# Altium schematic sheet 09 F411 1S AIO -F411 V1.1.SchDoc
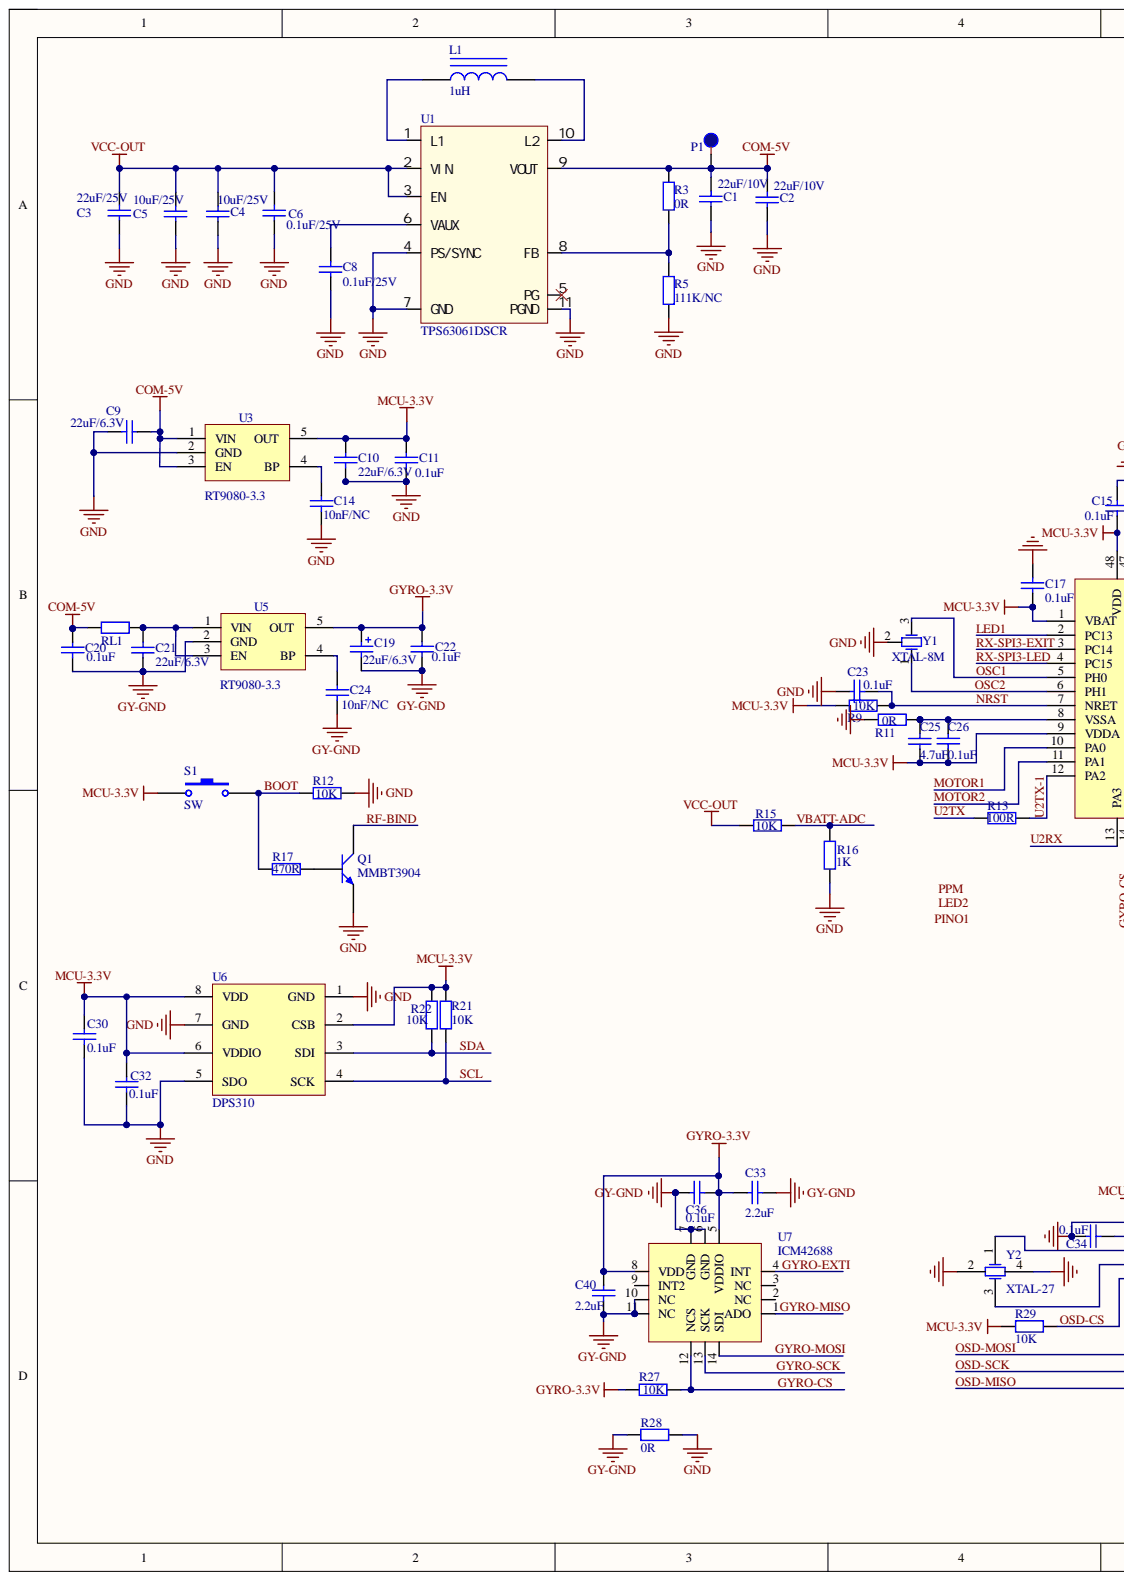
[diagram p0: 09 F411 1S AIO -F411 V1.1.SchDoc, left]
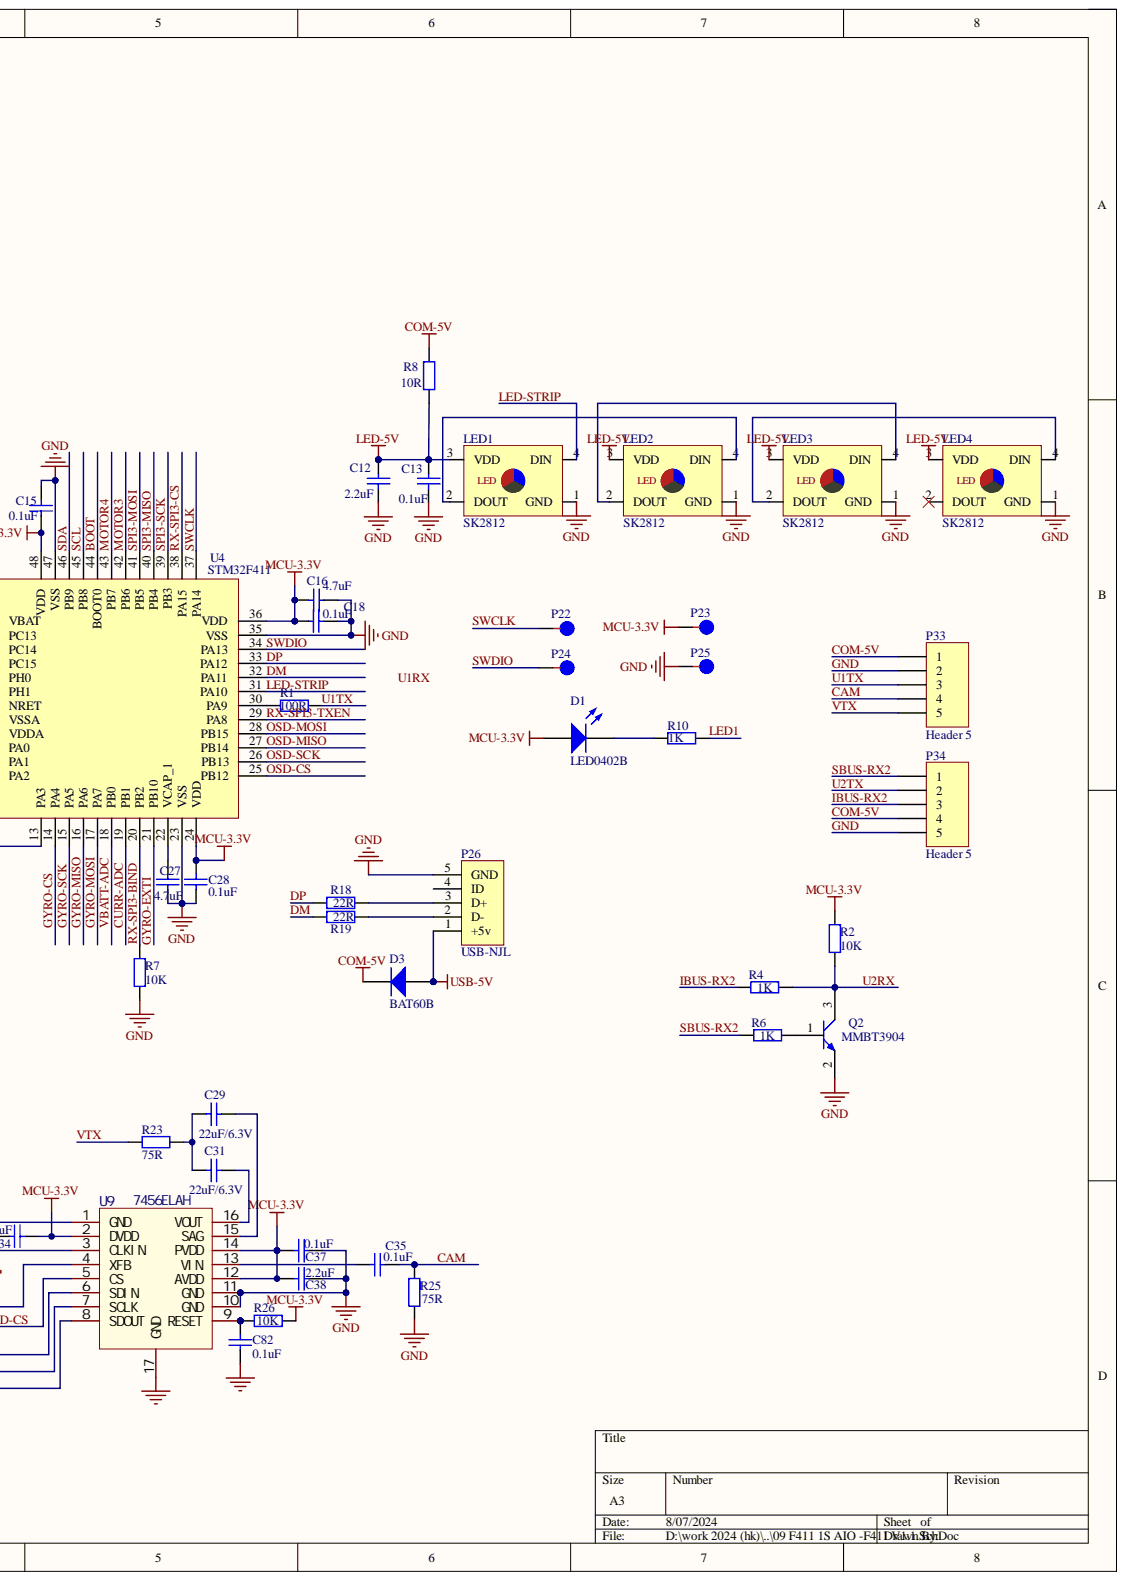
[diagram p1: 09 F411 1S AIO -F411 V1.1.SchDoc, right]

## Parts
C1  22uF/10V  [C0603]
C2  22uF/10V  [C0603]
C3  22uF/25V  [C0805]
C4  10uF/25V  [C0603]
C5  10uF/25V  [C0603]
C6  0.1uF/25V  [C0402]
C8  0.1uF/25V  [C0402]
C9  22uF/6.3V  [C0603]
C10  22uF/6.3V  [C0603]
C11  0.1uF  [C0201]
C12  0.1uF  value 2.2uF  [C0201]
C13  0.1uF  [C0201]
C14  10nF/NC  [C0201]
C15  0.1uF  [C0201]
C16  4.7uF  [C0201]
C17  0.1uF  [C0201]
C18  0.1uF  [C0201]
C19  22uF/6.3V  [C0805-TAN]
C20  0.1uF  [C0201]
C21  22uF/6.3V  [C0603]
C22  0.1uF  [C0201]
C23  0.1uF  [C0201]
C24  10nF/NC  [C0201]
C25  4.7uF  [C0201]
C26  0.1uF  [C0201]
C27  4.7uF  [C0201]
C28  0.1uF  [C0201]
C29  22uF  value 22uF/6.3V  [C0603]
C30  0.1uF  [C0201]
C31  22uF  value 22uF/6.3V  [C0603]
C32  0.1uF  [C0201]
C33  2.2uF  [C0201]
C34  0.1uF  [C0201]
C35  0.1uF  [C0201]
C36  0.1uF  [C0201]
C37  0.1uF  [C0201]
C38  2.2uF  [C0201]
C40  2.2uF  [C0201]
C82  0.1uF  [C0201]
D1  LED0402B  [LED0402B]
D3  BAT60B  [BAT60B]
L1  1uH  [L3*3]
LED1  SK2812  [LEDWS2812-C]
LED2  SK2812  [LEDWS2812-C]
LED3  SK2812  [LEDWS2812-C]
LED4  SK2812  [LEDWS2812-C]
P1  (no value)  [TP08]
P22  (no value)  [TP08]
P23  (no value)  [TP08]
P24  (no value)  [TP08]
P25  (no value)  [TP08]
P26  USB-NJL  [USB-C2909202]
P33  Header 5  [SURS08-5P]
P34  Header 5  [SURS08-5P]
Q1  MMBT3904  [SOT-723-3]
Q2  MMBT3904  [SOT-723-3]
R1  100R  [R0201]
R2  10K  [R0201]
R3  0R  [R0201]
R4  1K  [R0201]
R5  111K/NC  [R0201]
R6  1K  [R0201]
R7  10K  [R0201]
R8  10R  [R0805]
R9  10K  [R0201]
R10  1K  [R0201]
R11  0R  [R0201]
R12  10K  [R0201]
R13  100R  [R0201]
R15  10K  [R0201]
R16  1K  [R0201]
R17  470R  [R0201]
R18  22R  [R0201]
R19  22R  [R0201]
R21  10K  [R0201]
R22  10K  [R0201]
R23  75R  [R0201]
R25  75R  [R0201]
R26  10K  [R0201]
R27  10K  [R0201]
R28  0R  [R0201]
R29  10K  [R0201]
RL1  FB  [R0402]  (value not drawn)
S1  SW  [SMD-2]
U1  TPS63061DSCR  [WSON-10P]
U3  RT9080-3.3  [RT9193]
U4  STM32F411  [STM32F411]
U5  RT9080-3.3  [RT9193]
U6  DPS310  [BMP280]
U7  ICM42688  [BMI270]
U9  7456ELAH  [7456F]
Y1  XTAL-8M  [XTAL3315]
Y2  XTAL-27  [XTL-2016]

## Nets
GY-GND: C19.2 C20.2 C21.1 C22.2 C24.2 C33.2 C36.1 C40.2 R28.2 U5.2(GND) U7.6(GND) U7.7(GND) U7.10(NC) U7.11(NC)
GYRO-3.3V: C19.1 C22.1 C33.1 C36.2 C40.1 R27.1 U5.5(OUT) U7.5(VDDIO) U7.8(VDD)
LED-5V: C12.1 C13.1 LED1.3(VDD) LED2.3(VDD) LED3.3(VDD) LED4.3(VDD) R8.2
MCU-3.3V: C10.1 C11.1 C15.1 C16.2 C17.1 C18.1 C25.1 C26.2 C28.2 C30.1 C32.1 C34.2 C37.2 C38.2 D1.1 P23.1 R2.1 R9.2 R21.2 R22.2 R26.2 R29.1 S1.1 U3.5(OUT) U4.1(VBAT) U4.9(VDDA) U4.24(VDD) U4.36(VDD) U4.48(VDD) U6.2(CSB) U6.6(VDDIO) U6.8(VDD) U9.2(DVDD) U9.12(AVDD) U9.14(PVDD)
USB-5V: D3.1 P26.1(+5v)
VCC-OUT: C3.2 C4.2 C5.2 C6.2 R15.1 U1.2(VIN) U1.3(EN)
BOOT: R12.2 R17.1 S1.2 U4.44(BOOT0)
CAM: C35.1 P33.4 R25.1
COM-5V: C1.2 C2.2 C9.1 C20.1 D3.2 P1.1 P33.1 P34.4 R3.1 R8.1 RL1.2 U1.9(VOUT) U3.1(VIN) U3.3(EN)
CURR-ADC: U4.19(PB1)
DM: R19.1 U4.32(PA11)
DP: R18.1 U4.33(PA12)
GND: C1.1 C2.1 C3.1 C4.1 C5.1 C6.1 C8.1 C9.2 C10.2 C11.2 C12.2 C13.2 C14.2 C15.2 C16.1 C17.2 C18.2 C23.1 C27.1 C28.1 C30.2 C32.2 C34.1 C37.1 C38.1 C82.1 LED1.1(GND) LED2.1(GND) LED3.1(GND) LED4.1(GND) P25.1 P26.5(GND) P33.2 P34.5 Q1.2 Q2.2 R5.2 R7.1 R11.2 R12.1 R16.2 R25.2 R28.1 U1.4(PS/SYNC) U1.7(GND) U1.11(PGND) U3.2(GND) U4.23(VSS) U4.35(VSS) U4.47(VSS) U6.1(GND) U6.5(SDO) U6.7(GND) U9.1(GND) U9.10(GND) U9.11(GND) U9.17(GND) Y1.2 Y2.2 Y2.4
GYRO-CS: R27.2 U4.14(PA4) U7.12(NCS)
GYRO-EXTI: U4.21(PB10) U7.4(INT)
GYRO-MISO: U4.16(PA6) U7.1(ADO)
GYRO-MOSI: U4.17(PA7) U7.14(SDI)
GYRO-SCK: U4.15(PA5) U7.13(SCK)
IBUS-RX2: P34.3 R4.2
LED1: R10.2 U4.2(PC13)
LED-STRIP: LED1.4(DIN) U4.31(PA10)
MOTOR1: U4.10(PA0)
MOTOR2: U4.11(PA1)
MOTOR3: U4.42(PB6)
MOTOR4: U4.43(PB7)
NRST: C23.2 R9.1 U4.7(NRET)
OSC1: U4.5(PH0) Y1.3
OSC2: U4.6(PH1) Y1.1
OSD-CS: R29.2 U4.25(PB12) U9.5(CS)
OSD-MISO: U4.27(PB14) U9.8(SDOUT)
OSD-MOSI: U4.28(PB15) U9.6(SDIN)
OSD-SCK: U4.26(PB13) U9.7(SCLK)
RF-BIND: Q1.3
RX-SPI3-BIND: R7.2 U4.20(PB2)
RX-SPI3-CS: U4.38(PA15)
RX-SPI3-EXIT: U4.3(PC14)
RX-SPI3-LED: U4.4(PC15)
RX-SPI3-TXEN: U4.29(PA8)
SBUS-RX2: P34.1 R6.2
SCL: R21.1 U4.45(PB8) U6.4(SCK)
SDA: R22.1 U4.46(PB9) U6.3(SDI)
SPI3-MISO: U4.40(PB4)
SPI3-MOSI: U4.41(PB5)
SPI3-SCK: U4.39(PB3)
SWCLK: P22.1 U4.37(PA14)
SWDIO: P24.1 U4.34(PA13)
U1TX: P33.3 R1.2
U2RX: Q2.3 R2.2 R4.1 U4.13(PA3)
U2TX: P34.2 R13.1
U2TX-1: R13.2 U4.12(PA2)
VBATT-ADC: R15.2 R16.1 U4.18(PB0)
VTX: P33.5 R23.1
NetC8_2: C8.2 U1.6(VAUX)
NetC14_1: C14.1 U3.4(BP)
NetC21_2: C21.2 RL1.1 U5.1(VIN) U5.3(EN)
NetC24_1: C24.1 U5.4(BP)
NetC25_2: C25.2 C26.1 R11.1 U4.8(VSSA)
NetC27_2: C27.2 U4.22(VCAP_1)
NetC29_1: C29.1 U9.15(SAG)
NetC29_2: C29.2 C31.2 R23.2
NetC31_1: C31.1 U9.16(VOUT)
NetC35_2: C35.2 U9.13(VIN)
NetC82_2: C82.2 R26.1 U9.9(RESET)
NetD1_2: D1.2 R10.1
NetL1_1: L1.1 U1.1(L1)
NetL1_2: L1.2 U1.10(L2)
NetLED1_2: LED1.2(DOUT) LED2.4(DIN)
NetLED2_2: LED2.2(DOUT) LED3.4(DIN)
NetLED3_2: LED3.2(DOUT) LED4.4(DIN)
NetP26_2: P26.2(D-) R19.2
NetP26_3: P26.3(D+) R18.2
NetQ1_1: Q1.1 R17.2
NetQ2_1: Q2.1 R6.1
NetR1_1: R1.1 U4.30(PA9)
NetR3_2: R3.2 R5.1 U1.8(FB)
NetU9_3: U9.3(CLKIN) Y2.1
NetU9_4: U9.4(XFB) Y2.3
LED2:
PINO1:
PPM:
U1RX:

Not connected: LED4 2(DOUT); P26 4(ID); U1 5(PG); U7 2(NC) 3(NC) 9(INT2)

## Library data of the parts
C1 C2 = C0805: Capacitor; Value: 22uF; Component Kind: Standard; Library Name: Integrated_Library_gr.IntLib
C3-C5 = C0805: Capacitor; Component Kind: Standard; Library Name: Integrated_Library_gr.IntLib
C6 C8 C11-C18 C20 C22-C28 C30 C32-C38 C40 C82 = C0201: Capacitor; Component Kind: Standard
  C6 C8 C14 C24: Library Name: Integrated_Library_gr.IntLib
  C11 C15 C17 C18 C20 C22 C23 C26 C28 C30 C32 C36: Library Name: Integrated_Library_gr.IntLib; Value: 0.1uF
  C12: Library Name: Miscellaneous Connectors.IntLib
  C13 C34 C35 C37 C82: Library Name: Miscellaneous Connectors.IntLib; Value: 0.1uF
  C16 C25 C27: Library Name: Integrated_Library_gr.IntLib; Value: 4.7uF
  C33 C40: Library Name: Integrated_Library_gr.IntLib; Value: 2.2uF
  C38: Library Name: Miscellaneous Connectors.IntLib; Value: 2.2uF
C9 C10 C21 = C0603: Capacitor; Value: 22uF; Component Kind: Standard; Library Name: Integrated_Library_gr.IntLib
C19 = DC1206: Capacitor; Value: 22uF; Component Kind: Standard; Library Name: Integrated_Library_gr.IntLib
C29 C31 = C0603: Capacitor; Component Kind: Standard; Library Name: Miscellaneous Connectors.IntLib
D1 = LED0603B: LED; Component Kind: Standard; Library Name: Integrated_Library_gr.IntLib
D3 = BAT60B: Diode; Component Kind: Standard; Library Name: Integrated_Library_gr.IntLib
L1 = L-7*7: Inductor; Component Kind: Standard; Library Name: Integrated_Library_gr.IntLib
LED1-LED4 = SK6812-2020LED: Component Kind: Standard; Library Name: AT32F421K8U7temp V1.0.SCHLIB
P1 P22-P25 = TP: Component Kind: Standard
P26 = USB-NJL: USB; Component Kind: Standard; Library Name: F722 BL-32 V2.0.SCHLIB
P33 P34 = Header 5: Header, 5-Pin; Component Kind: Standard; LatestRevisionDate: 17-Jul-2002; LatestRevisionNote: Re-released for DXP Platform.; Library Name: Miscellaneous Connectors.IntLib; Signal Integrity: Connector; Publisher: Altium Limited
Q1 Q2 = 3904-23: NPN; Component Kind: Standard
  Q1: Library Name: Integrated_Library_gr.IntLib
  Q2: Library Name: Miscellaneous Connectors.IntLib
R1 R5 R13 = R0201: Resistor; Value: 10K; Component Kind: Standard; Library Name: Integrated_Library_gr.IntLib
R2-R4 R6-R12 R15-R19 R21-R23 R25-R29 = R0201: Resistor; Component Kind: Standard
  R2 R4 R6 R8 R25: Library Name: AT32F421K8U7temp V1.0.SCHLIB
  R3 R7: Library Name: Integrated_Library_gr.IntLib
  R9 R12 R15 R21 R22 R27: Library Name: Integrated_Library_gr.IntLib; Value: 10K
  R10 R16: Library Name: Integrated_Library_gr.IntLib; Value: 1K
  R11 R28: Library Name: Integrated_Library_gr.IntLib; Value: 0R
  R17: Library Name: Integrated_Library_gr.IntLib; Value: 470R
  R18 R19: Library Name: Integrated_Library_gr.IntLib; Value: 22R
  R23: Library Name: AT32F421K8U7temp V1.0.SCHLIB; Value: 75R
  R26 R29: Library Name: AT32F421K8U7temp V1.0.SCHLIB; Value: 10K
S1 = SW: SWITCH; Component Kind: Standard; Library Name: Integrated_Library_gr.IntLib
U3 U5 = RT9193: IC; Component Kind: Standard; Library Name: Integrated_Library_gr.IntLib
U6 = BMP280: Component Kind: Standard; Library Name: AT32F421K8U7temp V1.0.SCHLIB
U7 = BMI270: Component Kind: Standard; Library Name: AT32F421K8U7temp V1.0.SCHLIB
U9 = AT7456ELAH: Add into BOM: yes; Component Kind: Standard; Convert to PCB: yes; Device: AT7456ELAH; Library Name: AT32F421K8U7temp V1.0.SCHLIB; Symbol: AT7456ELAH
Y1 = XTAL-8M: OSC; Component Kind: Standard; Library Name: F722 BL-32 V2.0.SCHLIB
Y2 = XTAL-27: OSC; Component Kind: Standard; Library Name: Miscellaneous Connectors.IntLib
RL1 = FB: Component Kind: Standard; Description: FB; Library Name: Integrated_Library_gr.IntLib
U1 = TPS63061DSCR: Component Kind: Standard; Library Name: AT32F421K8U7temp V1.0.SCHLIB
U4 = STM32F411: Component Kind: Standard; Library Name: Integrated_Library_gr.IntLib
